# Altium schematic sheet [02]-LTC6803.SchDoc
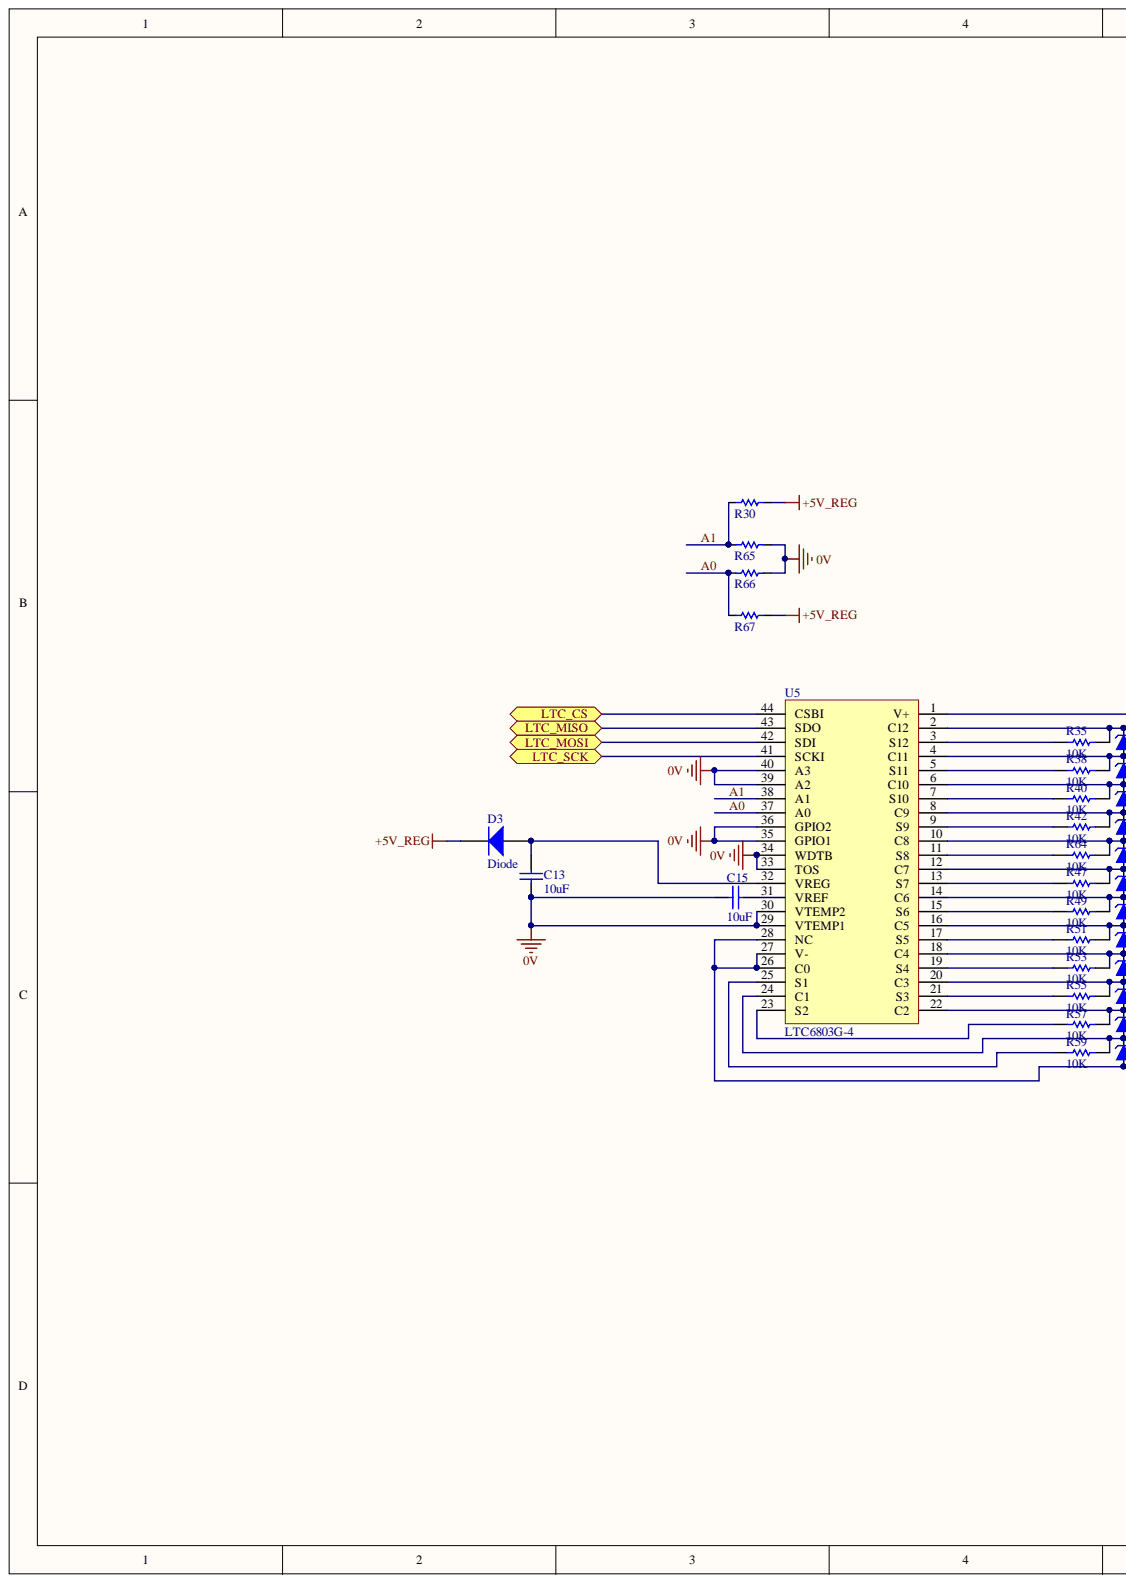
[diagram p0: [02]-LTC6803.SchDoc, left]
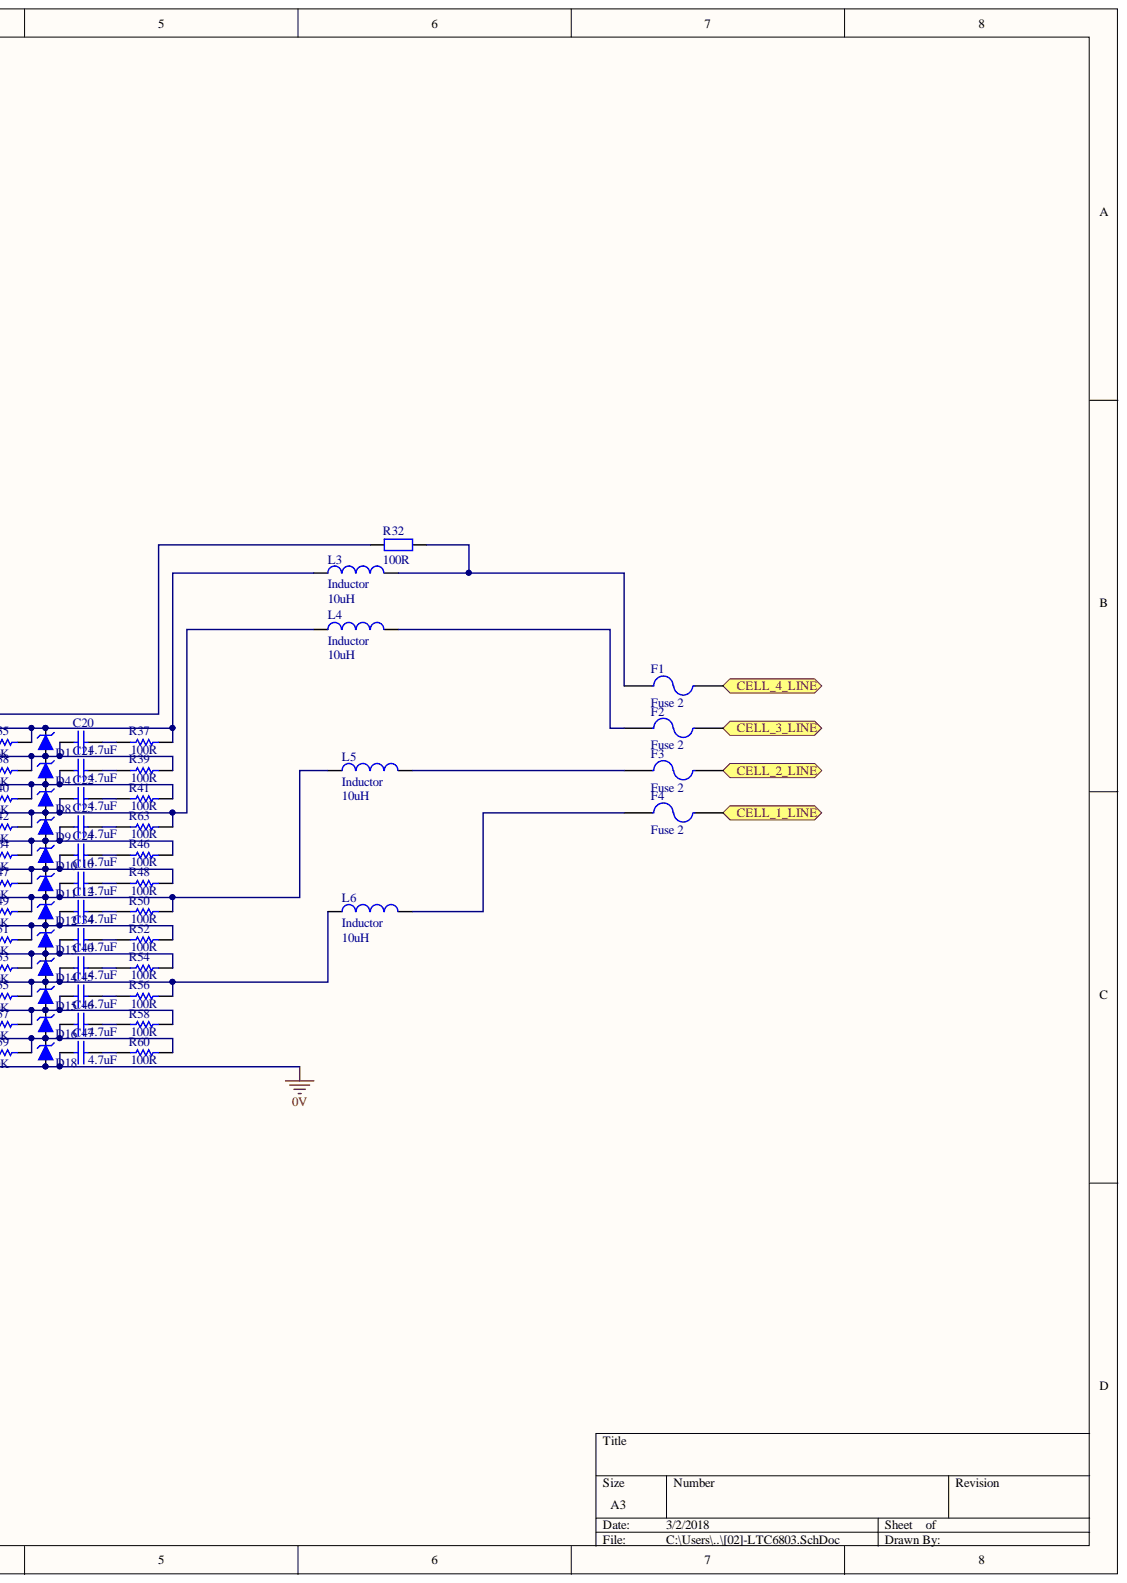
[diagram p1: [02]-LTC6803.SchDoc, right]

## Parts
C10  value 4.7uF  [cap-0603]  (hidden comment: Cap-0603)
C12  value 4.7uF  [cap-0603]  (hidden comment: Cap-0603)
C13  value 10uF  [cap-0603]  (hidden comment: Cap-0603)
C15  value 10uF  [cap-0603]  (hidden comment: Cap-0603)
C20  value 4.7uF  [cap-0603]  (hidden comment: Cap-0603)
C21  value 4.7uF  [cap-0603]  (hidden comment: Cap-0603)
C22  value 4.7uF  [cap-0603]  (hidden comment: Cap-0603)
C23  value 4.7uF  [cap-0603]  (hidden comment: Cap-0603)
C24  value 4.7uF  [cap-0603]  (hidden comment: Cap-0603)
C34  value 4.7uF  [cap-0603]  (hidden comment: Cap-0603)
C40  value 4.7uF  [cap-0603]  (hidden comment: Cap-0603)
C45  value 4.7uF  [cap-0603]  (hidden comment: Cap-0603)
C46  value 4.7uF  [cap-0603]  (hidden comment: Cap-0603)
C47  value 4.7uF  [cap-0603]  (hidden comment: Cap-0603)
D1  D Zener  [DIO_SMD_SOD80]  (value not drawn)
D3  Diode  [SOD323D]
D4  D Zener  [DIO_SMD_SOD80]  (value not drawn)
D8  D Zener  [DIO_SMD_SOD80]  (value not drawn)
D9  D Zener  [DIO_SMD_SOD80]  (value not drawn)
D10  D Zener  [DIO_SMD_SOD80]  (value not drawn)
D11  D Zener  [DIO_SMD_SOD80]  (value not drawn)
D12  D Zener  [DIO_SMD_SOD80]  (value not drawn)
D13  D Zener  [DIO_SMD_SOD80]  (value not drawn)
D14  D Zener  [DIO_SMD_SOD80]  (value not drawn)
D15  D Zener  [DIO_SMD_SOD80]  (value not drawn)
D16  D Zener  [DIO_SMD_SOD80]  (value not drawn)
D18  D Zener  [DIO_SMD_SOD80]  (value not drawn)
F1  Fuse 2  [fuse_1812]
F2  Fuse 2  [fuse_1812]
F3  Fuse 2  [fuse_1812]
F4  Fuse 2  [fuse_1812]
L3  Inductor  value 10uH  [Inductor-1206]
L4  Inductor  value 10uH  [Inductor-1206]
L5  Inductor  value 10uH  [Inductor-1206]
L6  Inductor  value 10uH  [Inductor-1206]
R30  1K  [Res-0603]  (value not drawn)
R32  100R  [res-1206]
R35  value 10K  [res-0603]  (hidden comment: Res-0603)
R37  value 100R  [res-0603]  (hidden comment: Res-0603)
R38  value 10K  [res-0603]  (hidden comment: Res-0603)
R39  value 100R  [res-0603]  (hidden comment: Res-0603)
R40  value 10K  [res-0603]  (hidden comment: Res-0603)
R41  value 100R  [res-0603]  (hidden comment: Res-0603)
R42  value 10K  [res-0603]  (hidden comment: Res-0603)
R46  value 100R  [res-0603]  (hidden comment: Res-0603)
R47  value 10K  [res-0603]  (hidden comment: Res-0603)
R48  value 100R  [res-0603]  (hidden comment: Res-0603)
R49  value 10K  [res-0603]  (hidden comment: Res-0603)
R50  value 100R  [res-0603]  (hidden comment: Res-0603)
R51  value 10K  [res-0603]  (hidden comment: Res-0603)
R52  value 100R  [res-0603]  (hidden comment: Res-0603)
R53  value 10K  [res-0603]  (hidden comment: Res-0603)
R54  value 100R  [res-0603]  (hidden comment: Res-0603)
R55  value 10K  [res-0603]  (hidden comment: Res-0603)
R56  value 100R  [res-0603]  (hidden comment: Res-0603)
R57  value 10K  [res-0603]  (hidden comment: Res-0603)
R58  value 100R  [res-0603]  (hidden comment: Res-0603)
R59  value 10K  [res-0603]  (hidden comment: Res-0603)
R60  value 100R  [res-0603]  (hidden comment: Res-0603)
R63  value 100R  [res-0603]  (hidden comment: Res-0603)
R64  value 10K  [res-0603]  (hidden comment: Res-0603)
R65  1K  [Res-0603]  (value not drawn)
R66  1K  [res-0603]  (value not drawn)
R67  1K  [Res-0603]  (value not drawn)
U5  LTC6803G-4  [SOP44]

## Ports
CELL_1_LINE, CELL_2_LINE, CELL_3_LINE, CELL_4_LINE, LTC_CS, LTC_MISO, LTC_MOSI, LTC_SCK

## Nets
0V: C13.1 C15.1 C47.2 D18.1 R65.2 R66.2 U5.26(C0) U5.27(V-) U5.28(NC) U5.29(VTEMP1) U5.30(VTEMP2) U5.33(TOS) U5.34(WDTB) U5.35(GPIO1) U5.36(GPIO2) U5.39(A2) U5.40(A3)
+5V_REG: D3.2 R30.2 R67.2
A0: R66.1 R67.1 U5.37(A0)
A1: R30.1 R65.1 U5.38(A1)
CELL_1_LINE: F4.2 ; port CELL_1_LINE
CELL_2_LINE: F3.2 ; port CELL_2_LINE
CELL_3_LINE: F2.2 ; port CELL_3_LINE
CELL_4_LINE: F1.2 ; port CELL_4_LINE
LTC_CS: U5.44(CSBI) ; port LTC_CS
LTC_MISO: U5.43(SDO) ; port LTC_MISO
LTC_MOSI: U5.42(SDI) ; port LTC_MOSI
LTC_SCK: U5.41(SCKI) ; port LTC_SCK
NetC10_1: C10.1 R48.1
NetC10_2: C10.2 D11.1 D12.2 L5.1 R49.2 R50.2 U5.14(C6)
NetC12_1: C12.1 R50.1
NetC12_2: C12.2 D12.1 D13.2 R51.2 R52.2 U5.16(C5)
NetC13_2: C13.2 D3.1 U5.32(VREG)
NetC15_2: C15.2 U5.31(VREF)
NetC20_1: C20.1 R37.1
NetC20_2: C20.2 D1.1 D4.2 R38.2 R39.2 U5.4(C11)
NetC21_1: C21.1 R39.1
NetC21_2: C21.2 D4.1 D8.2 R40.2 R41.2 U5.6(C10)
NetC22_1: C22.1 R41.1
NetC22_2: C22.2 D8.1 D9.2 L4.1 R42.2 R63.2 U5.8(C9)
NetC23_1: C23.1 R63.1
NetC23_2: C23.2 D9.1 D10.2 R46.2 R64.2 U5.10(C8)
NetC24_1: C24.1 R46.1
NetC24_2: C24.2 D10.1 D11.2 R47.2 R48.2 U5.12(C7)
NetC34_1: C34.1 R52.1
NetC34_2: C34.2 D13.1 D14.2 R53.2 R54.2 U5.18(C4)
NetC40_1: C40.1 R54.1
NetC40_2: C40.2 D14.1 D15.2 L6.1 R55.2 R56.2 U5.20(C3)
NetC45_1: C45.1 R56.1
NetC45_2: C45.2 D15.1 D16.2 R57.2 R58.2 U5.22(C2)
NetC46_1: C46.1 R58.1
NetC46_2: C46.2 D16.1 D18.2 R59.2 R60.2 U5.24(C1)
NetC47_1: C47.1 R60.1
NetD1_2: D1.2 L3.1 R35.2 R37.2 U5.2(C12)
NetF1_1: F1.1 L3.2 R32.2
NetF2_1: F2.1 L4.2
NetF3_1: F3.1 L5.2
NetF4_1: F4.1 L6.2
NetR32_1: R32.1 U5.1(V+)
NetR35_1: R35.1 U5.3(S12)
NetR38_1: R38.1 U5.5(S11)
NetR40_1: R40.1 U5.7(S10)
NetR42_1: R42.1 U5.9(S9)
NetR47_1: R47.1 U5.13(S7)
NetR49_1: R49.1 U5.15(S6)
NetR51_1: R51.1 U5.17(S5)
NetR53_1: R53.1 U5.19(S4)
NetR55_1: R55.1 U5.21(S3)
NetR57_1: R57.1 U5.23(S2)
NetR59_1: R59.1 U5.25(S1)
NetR64_1: R64.1 U5.11(S8)

## Library data of the parts
C10 C12 C13 C15 C20-C24 C34 C40 C45-C47 = Cap-0603: Capacitor (Semiconductor SIM Model); Component Kind: Standard; LatestRevisionDate: 29-May-2009; LatestRevisionNote: IPC-7351 Footprint Added.; Library Name: Devices.SchLib; PackageDescription: Chip Capacitor; PackageReference: C1206; Published: 8-Jun-2000; Publisher: Altium Limited
D1 D4 D8-D16 D18 = D Zener: Zener Diode; Component Kind: Standard; LatestRevisionDate: 17-Jul-2002; LatestRevisionNote: Re-released for DXP Platform.; Library Name: Devices.SchLib; PackageDescription: Diode, Thru-Hole; 2 Leads; 0.7 in Pin Spacing; PackageReference: DIODE-0.7; Published: 8-Jun-2000; Publisher: Altium Limited
D3 = Diode: Default Diode; Code_JEDEC: DO-214-AB; Component Kind: Standard; LatestRevisionDate: 17-Jul-2002; LatestRevisionNote: Re-released for DXP Platform.; PackageReference: SMC; PackageVersion: Sep-1996; Published: 8-Jun-2000; Library Name: THUVIENTUTAO.lib; Publisher: Altium Limited
F1-F4 = Fuse 2: Fuse; Component Kind: Standard; LatestRevisionDate: 17-Jul-2002; LatestRevisionNote: Re-released for DXP Platform.; PackageReference: PIN-W2/E2.8; Published: 8-Jun-2000; Library Name: THUVIENTUTAO.lib; Publisher: Altium Limited
L3 = Inductor: Code_IEC: 1005; Value: 10uH; Code_JEITA: 0402; Component Kind: Standard; Description: Inductor; LatestRevisionDate: 29-May-2009; LatestRevisionNote: IPC-7351 Footprint Added.; Library Name: Devices.SchLib; PackageDescription: Chip Inductor; PackageReference: 0402-A; Published: 8-Jun-2000; Signal Integrity: Inductor; Simulation: INDUCTOR; Publisher: Altium Limited
L4-L6 = Inductor: Code_IEC: 1005; Code_JEITA: 0402; Component Kind: Standard; Description: Inductor; LatestRevisionDate: 29-May-2009; LatestRevisionNote: IPC-7351 Footprint Added.; Library Name: Devices.SchLib; PackageDescription: Chip Inductor; PackageReference: 0402-A; Published: 8-Jun-2000; Signal Integrity: Inductor; Simulation: INDUCTOR; Publisher: Altium Limited
R30 R65-R67 = Res-0402: Resistor; Component Kind: Standard; LatestRevisionDate: 17-Jul-2002; LatestRevisionNote: Re-released for DXP Platform.; PackageDescription: Axial Device, Thru-Hole; 2 Leads; 0.3 in Pin Spacing; PackageReference: AXIAL-0.3; Published: 8-Jun-2000; Library Name: THUVIENTUTAO.lib; Publisher: Altium Limited
R32 = R1/4W: Resistor 1/4W; Value: R1/4W - 1K; Component Kind: Standard; Library Name: Cty1.SchLib
R35 R37-R42 R46-R60 R63 R64 = Res-0603: Resistor; Component Kind: Standard; LatestRevisionDate: 17-Jul-2002; LatestRevisionNote: Re-released for DXP Platform.; Library Name: Devices.SchLib; PackageDescription: Axial Device, Thru-Hole; 2 Leads; 0.3 in Pin Spacing; PackageReference: AXIAL-0.3; Published: 8-Jun-2000; Publisher: Altium Limited
U5 = LTC6803G-4: Component Kind: Standard; Library Name: THUVIENTUTAO.lib
